# Altium schematic sheet Keypad.SchDoc
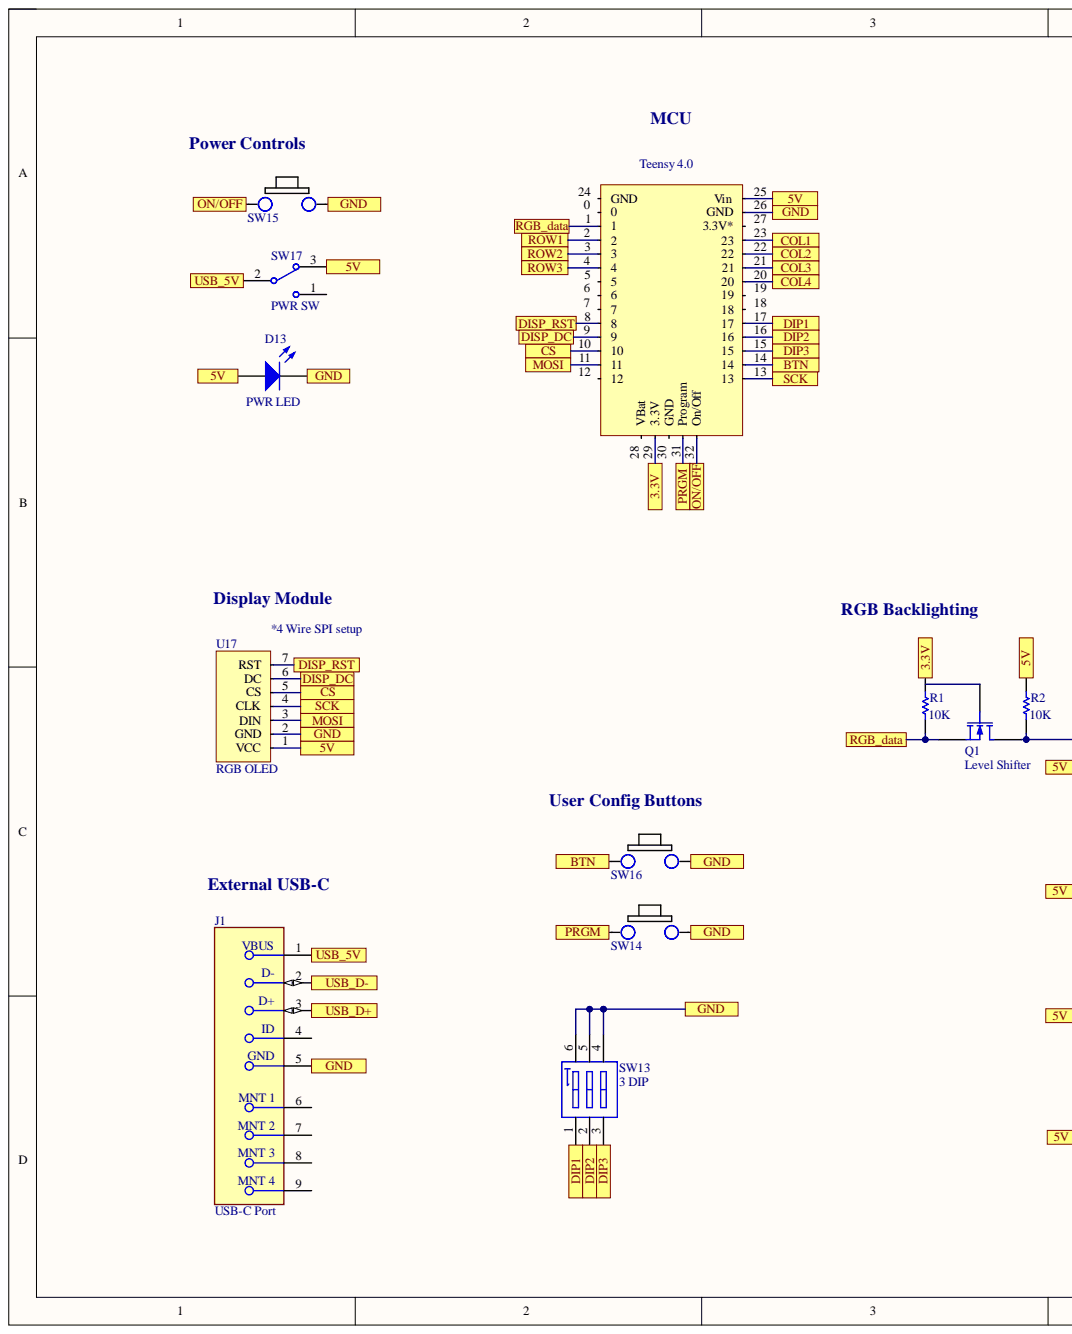
[diagram p0: Keypad.SchDoc, left]
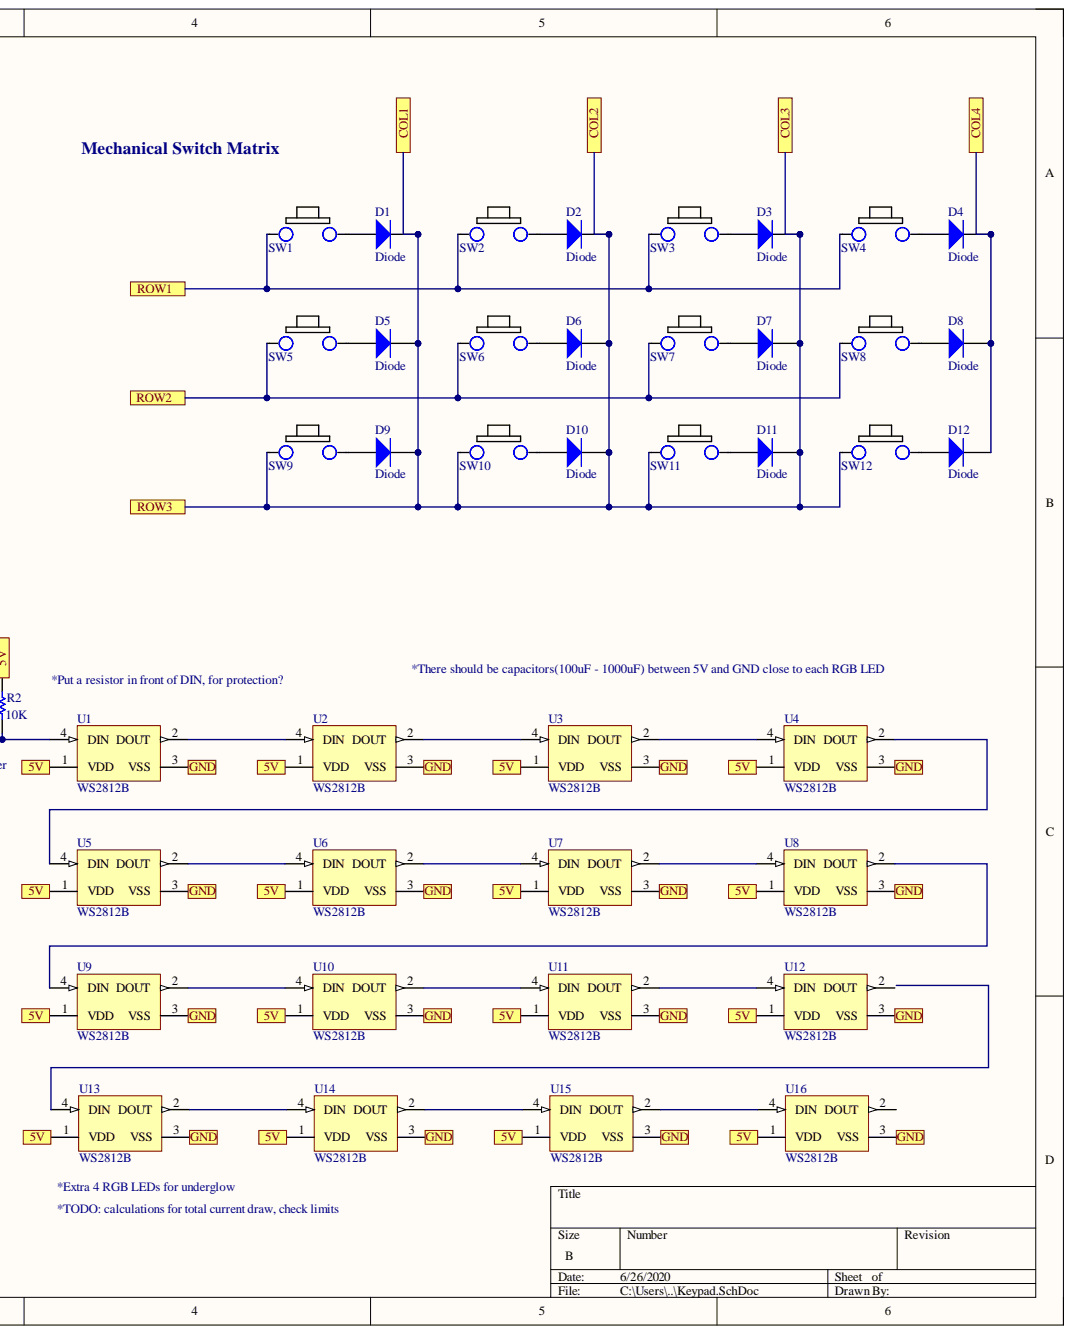
[diagram p1: Keypad.SchDoc, right]

## Parts
D1  Diode  [SMC]
D2  Diode  [SMC]
D3  Diode  [SMC]
D4  Diode  [SMC]
D5  Diode  [SMC]
D6  Diode  [SMC]
D7  Diode  [SMC]
D8  Diode  [SMC]
D9  Diode  [SMC]
D10  Diode  [SMC]
D11  Diode  [SMC]
D12  Diode  [SMC]
D13  PWR LED  [LED-0]
J1  USB-C Port  [FP-678038020-MFG]
Q1  Level Shifter  [E3]
R1  value 10K  [AXIAL-0.3]  (hidden comment: Res1)
R2  value 10K  [AXIAL-0.3]  (hidden comment: Res1)
SW1  SW?
SW2  SW?
SW3  SW?
SW4  SW?
SW5  SW?
SW6  SW?
SW7  SW?
SW8  SW?
SW9  SW?
SW10  SW?
SW11  SW?
SW12  SW?
SW13  3 DIP  [418121160803]
SW14  SW?
SW15  SW?
SW16  SW?
SW17  PWR SW  [TL36WW15050]
Teensy4  Teensy 4
U1  WS2812B  [ADA-RGB-1655_V]
U2  WS2812B  [ADA-RGB-1655_V]
U3  WS2812B  [ADA-RGB-1655_V]
U4  WS2812B  [ADA-RGB-1655_V]
U5  WS2812B  [ADA-RGB-1655_V]
U6  WS2812B  [ADA-RGB-1655_V]
U7  WS2812B  [ADA-RGB-1655_V]
U8  WS2812B  [ADA-RGB-1655_V]
U9  WS2812B  [ADA-RGB-1655_V]
U10  WS2812B  [ADA-RGB-1655_V]
U11  WS2812B  [ADA-RGB-1655_V]
U12  WS2812B  [ADA-RGB-1655_V]
U13  WS2812B  [ADA-RGB-1655_V]
U14  WS2812B  [ADA-RGB-1655_V]
U15  WS2812B  [ADA-RGB-1655_V]
U16  WS2812B  [ADA-RGB-1655_V]
U17  RGB OLED

## Ports
3.3V, 5V, BTN, COL1, COL2, COL3, COL4, CS, DIP1, DIP2, DIP3, DISP_DC, DISP_RST, GND, MOSI, ON/OFF, PRGM, RGB_data, ROW1, ROW2, ROW3, SCK, USB_5V, USB_D+, USB_D-

## Nets
3.3V: R1.2 Teensy4.29(3.3V) ; port 3.3V
5V: D13.1 R2.2 SW17.3 Teensy4.25(Vin) U1.1(VDD) U2.1(VDD) U3.1(VDD) U4.1(VDD) U5.1(VDD) U6.1(VDD) U7.1(VDD) U8.1(VDD) U9.1(VDD) U10.1(VDD) U11.1(VDD) U12.1(VDD) U13.1(VDD) U14.1(VDD) U15.1(VDD) U16.1(VDD) U17.1(VCC) ; port 5V
BTN: SW16.11 Teensy4.14 ; port BTN
COL1: D1.2 D2.2 D3.2 D5.2 D6.2 D7.2 D9.2 D10.2 D11.2 SW9.11 SW10.11 SW11.11 SW12.11 Teensy4.4 Teensy4.21 Teensy4.22 Teensy4.23 ; port COL1, port COL2, port COL3, port ROW3
COL4: D4.2 D8.2 D12.2 Teensy4.20 ; port COL4
CS: Teensy4.10 U17.5 ; port CS
DIP1: SW13.1 Teensy4.17 ; port DIP1
DIP2: SW13.2 Teensy4.16 ; port DIP2
DIP3: SW13.3 Teensy4.15 ; port DIP3
DISP_DC: Teensy4.9 U17.6(DC) ; port DISP_DC
DISP_RST: Teensy4.8 U17.7(RST) ; port DISP_RST
GND: D13.2 J1.5 SW13.4 SW13.5 SW13.6 SW14.10 SW15.10 SW16.10 Teensy4.26(GND) U1.3(VSS) U2.3(VSS) U3.3(VSS) U4.3(VSS) U5.3(VSS) U6.3(VSS) U7.3(VSS) U8.3(VSS) U9.3(VSS) U10.3(VSS) U11.3(VSS) U12.3(VSS) U13.3(VSS) U14.3(VSS) U15.3(VSS) U16.3(VSS) U17.2(GND) ; port GND
MOSI: Teensy4.11 U17.3(DIN) ; port MOSI
ON/OFF: SW15.11 Teensy4.32(On/Off) ; port ON/OFF
PRGM: SW14.11 Teensy4.31(Program) ; port PRGM
RGB_data: Q1.3 R1.1 Teensy4.1 ; port RGB_data
ROW1: SW1.11 SW2.11 SW3.11 SW4.11 Teensy4.2 ; port ROW1
ROW2: SW5.11 SW6.11 SW7.11 SW8.11 Teensy4.3 ; port ROW2
SCK: Teensy4.13 U17.4(CLK) ; port SCK
USB_5V: J1.1 SW17.2 ; port USB_5V
NetD1_1: D1.1 SW1.10
NetD2_1: D2.1 SW2.10
NetD3_1: D3.1 SW3.10
NetD4_1: D4.1 SW4.10
NetD5_1: D5.1 SW5.10
NetD6_1: D6.1 SW6.10
NetD7_1: D7.1 SW7.10
NetD8_1: D8.1 SW8.10
NetD9_1: D9.1 SW9.10
NetD10_1: D10.1 SW10.10
NetD11_1: D11.1 SW11.10
NetD12_1: D12.1 SW12.10
NetJ1_2: J1.2
NetJ1_3: J1.3
NetQ1_1: Q1.1 R2.1 U1.4(DIN)
NetU1_2: U1.2(DOUT) U2.4(DIN)
NetU2_2: U2.2(DOUT) U3.4(DIN)
NetU3_2: U3.2(DOUT) U4.4(DIN)
NetU4_2: U4.2(DOUT) U5.4(DIN)
NetU5_2: U5.2(DOUT) U6.4(DIN)
NetU6_2: U6.2(DOUT) U7.4(DIN)
NetU7_2: U7.2(DOUT) U8.4(DIN)
NetU8_2: U8.2(DOUT) U9.4(DIN)
NetU9_2: U9.2(DOUT) U10.4(DIN)
NetU10_2: U10.2(DOUT) U11.4(DIN)
NetU11_2: U11.2(DOUT) U12.4(DIN)
NetU13_2: U13.2(DOUT) U14.4(DIN)
NetU14_2: U14.2(DOUT) U15.4(DIN)
NetU15_2: U15.2(DOUT) U16.4(DIN)

Not connected: J1 4 6 7 8 9; Q1 2; SW17 1; Teensy4 0 5 6 7 12 18 19 24(GND) 27(3.3V*) 28(VBat) 30(GND); U12 2(DOUT); U13 4(DIN); U16 2(DOUT)

## Library data of the parts
D1-D12 = Diode: Default Diode; Code_JEDEC: DO-214-AB; Component Kind: Standard; LatestRevisionDate: 17-Jul-2002; LatestRevisionNote: Re-released for DXP Platform.; Library Name: Miscellaneous Devices.IntLib; PackageDescription: DO-214-AB/SMC; 2 C-Bend Leads; Body 7.9 x 5.9 mm, inc. leads (LxW); PackageReference: SMC; PackageVersion: Sep-1996; Published: 8-Jun-2000; Signal Integrity: Diode; Simulation: DIODE; Publisher: Altium Limited
D13 = LED0: Typical INFRARED GaAs LED; Component Kind: Standard; LatestRevisionDate: 08-Jul-2005; LatestRevisionNote: Mech Layer 1 removed.; Library Name: Miscellaneous Devices.IntLib; PackageDescription: LED; 2 Leads; PackageReference: LED-0; Published: 8-Jun-2000; Simulation: LED0; Publisher: Altium Limited
J1 = CMP-04767-000002-1: CONN RCPT USB2.0 MINI AB SMD R/A; Manufacturer: Molex; Manufacturer Part Number: 678038020; Conn Orientation: Right Angle; Current Rating: 1A; Is Female: yes; Number Of Contacts: 5; Pitch: 0.8mm; Shielding: true; Voltage Rating: 30V; Ambient Temperature Range High: +50°C; Ambient Temperature Range Low: 0°C; Automotive: No; Category: Conn; Component Kind: Standard; ComponentLink2Description: Datasheet; ComponentLink2URL: https://www.molex.com/webdocs/datasheets/pdf/en-us/0678038020_IO_CONNECTORS.pdf; Contact Material: Gold,Nickel; Device Class L1: Connectors; Device Class L2: USB Connectors; Device Class L3: unset; Digikey Description: CONN RCPT USB2.0 MINI AB SMD R/A; Footprint Url: https://www.molex.com/pdm_docs/sd/678038020_sd.pdf; Height: 4.1mm; Lead Free: Yes; Library Name: Altium Content Vault; Mouser Description: Conn Mini-USB Type AB RCP 5 POS 0.8mm Solder RA SMD 5 Terminal 1 Port Embossed T/R; Octopart: https://octopart.com/67803-8020-molex-7136109; Package: 0; RoHS: TRUE; Temperature: 0°C to +50°C; Temperature Range High: +50°C; Temperature Range Low: 0°C; Usb Standard: USB 2.0
Q1 = MOSFET-N: N-Channel MOSFET; Component Kind: Standard; LatestRevisionDate: 17-Jul-2002; LatestRevisionNote: Re-released for DXP Platform.; Library Name: Miscellaneous Devices.IntLib; PackageDescription: TO, Flat Index; 3 In-Line, Axial Leads; Body Dia. 4.6mm; Lead Dia. 0.5 mm (max); PackageReference: E3; PackageVersion: 1995/1996; Published: 8-Jun-2000; Simulation: NMOS; Publisher: Altium Limited
R1 R2 = Res1: Resistor; Component Kind: Standard; LatestRevisionDate: 17-Jul-2002; LatestRevisionNote: Re-released for DXP Platform.; Library Name: Miscellaneous Devices.IntLib; PackageDescription: Axial Device, Thru-Hole; 2 Leads; 0.3 in Pin Spacing; PackageReference: AXIAL-0.3; Published: 8-Jun-2000; Signal Integrity: Res; Simulation: RESISTOR; Publisher: Altium Limited
SW13 = CMP-1437-00003-1: WS-DISV 2.54 mm small compact SMD Dip Switch with top tape; Manufacturer: Wuerth Elektronik; NoPins: 06; Component Kind: Standard; ComponentLink1Description: Manufacturer URL; ComponentLink1URL: http://www.we-online.com; ComponentLink2Description: Datasheet; ComponentLink2URL: http://katalog.we-online.de/em/datasheet/4181211608xx.pdf; Library Name: Altium Content Vault; PackageReference: 418121160803; PartNumber: 418121160803
SW17 = SW-SPDT: SPDT Subminiature Toggle Switch, Right Angle Mounting, Vertical Actuation; Component Kind: Standard; ComponentLink1Description: Manufacturer Link; ComponentLink1URL: http://www.apem.com; DatasheetVersion: 05-Dec-2000; LatestRevisionDate: 17-Dec-2003; LatestRevisionNote: Schematic revised, PCB Footprint replaced.; Library Name: Miscellaneous Devices.IntLib; PackageDescription: Switch; 4 Leads; PackageReference: TL36WW15050; Published: 24-Mar-1999; Publisher: Altium Limited
Teensy4 = Teensy4: Parameter 1: TEST; Component Kind: Standard; Library Name: Schlib1.SchLib
U1-U16 = CMP-2000-05026-1: Intelligent Control LED Integrated Light Source, 3.5 to 5.3 V, -25 to 80 degC, 4-Pin SMD, RoHS, Tape and Reel; Manufacturer: Adafruit-Industries-LLC; Configuration: Discrete; Number of LEDs: 10; Utilized LED: WS2812(5050); Category: Optoelectronics; Color: Red,Green,Blue(RGB); Component Kind: Standard; Controller Type: -; Datasheet URL: http://datasheet.octopart.com/ADA1655-Adafruit-Industries-datasheet-32028139.pdf; Datasheet Version: May-13; Family: Addressable,Specialty; Interface: -; Library Name: Altium Content Vault; Manufacturer URL: https://www.adafruit.com/; Mounting Type: SurfaceMount; Package Description: 4-Pin Surface Mount Device, Body 5 x 5 mm; Package Reference: 1655; Series: Neopixel; Size / Dimension: 5.00mmLx5.00mmW
U17 = Waveshare_OLED_RGB: Component Kind: Standard; Library Name: Schlib1.SchLib
SW1-SW12 SW14-SW16 = SW?: Component Kind: Standard; Library Name: Schlib1.SchLib
